# Altium schematic sheet 12_PCIE-x4.SchDoc
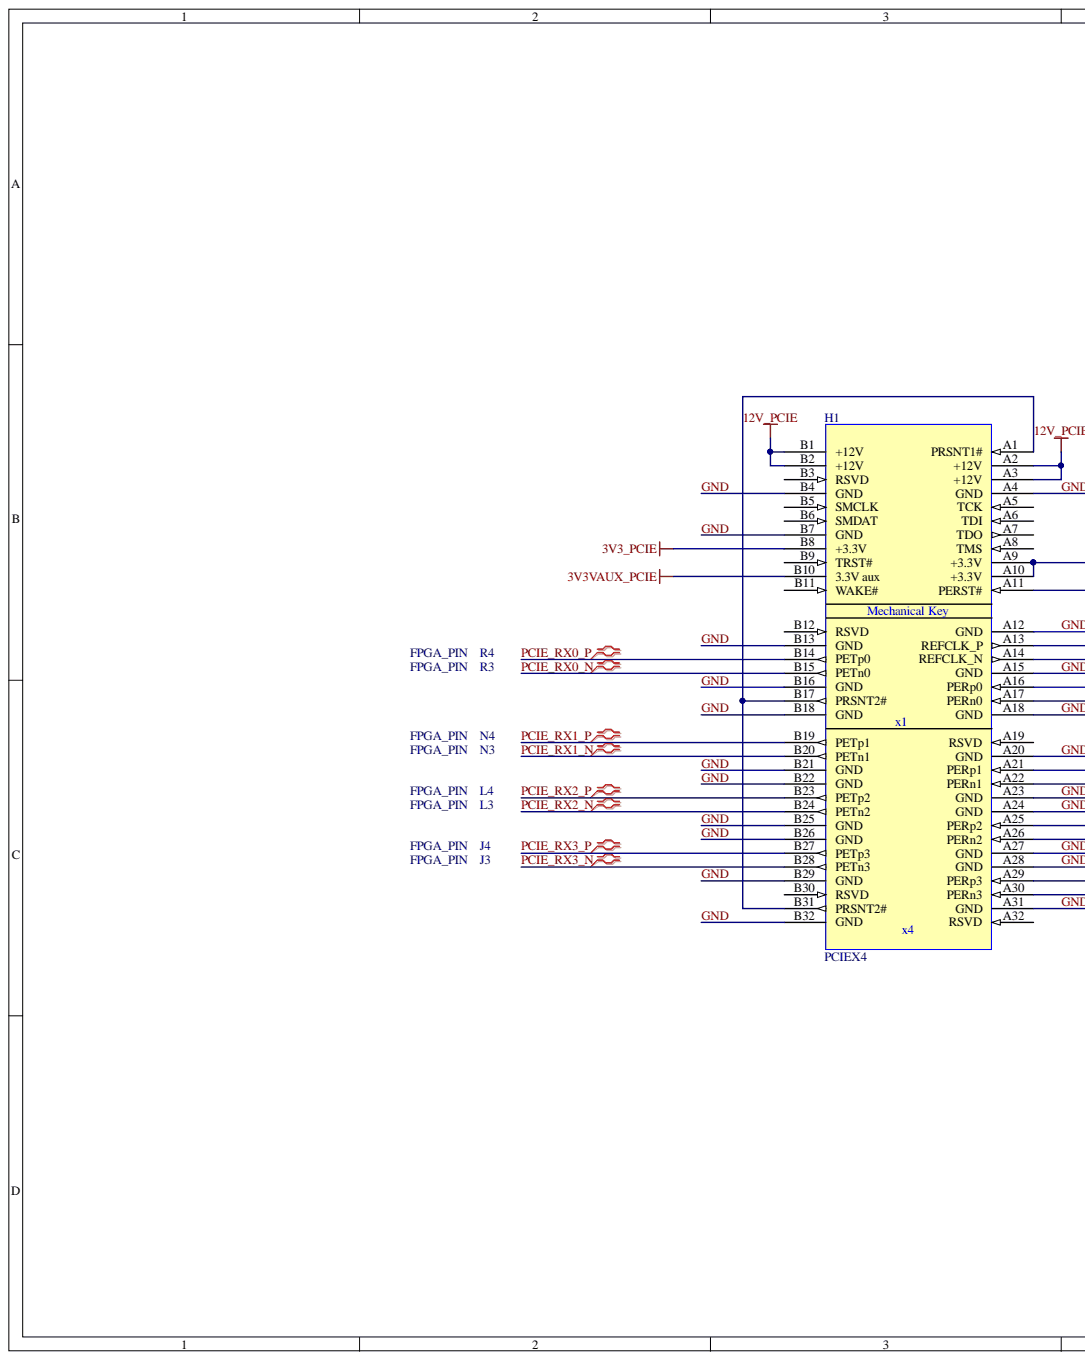
[diagram p0: 12_PCIE-x4.SchDoc, left]
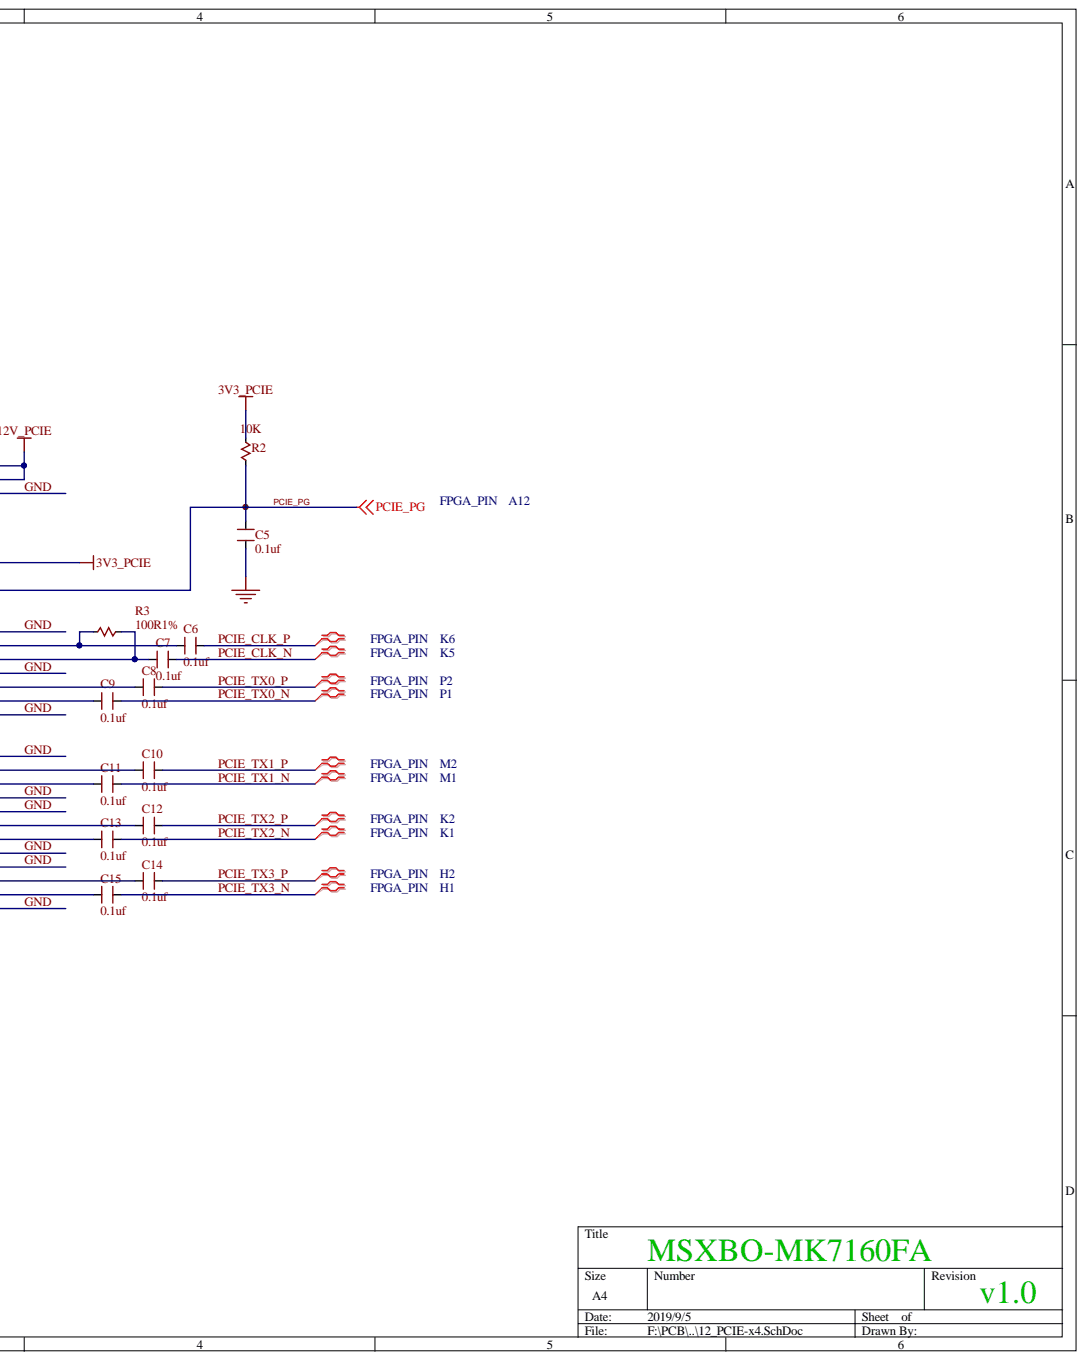
[diagram p1: 12_PCIE-x4.SchDoc, right]

## Parts
C5  0.1uf  [CAP0402]
C6  0.1uf  [CAP0402]
C7  0.1uf  [CAP0402]
C8  0.1uf  [CAP0402]
C9  0.1uf  [CAP0402]
C10  0.1uf  [CAP0402]
C11  0.1uf  [CAP0402]
C12  0.1uf  [CAP0402]
C13  0.1uf  [CAP0402]
C14  0.1uf  [CAP0402]
C15  0.1uf  [CAP0402]
H1  PCIEX4  [PCIEX4]
R2  10K  [R0603]
R3  100R1%  [R0402]

## Nets
3V3_PCIE: H1.A9(+3.3V) H1.A10(+3.3V) H1.B8(+3.3V) R2.1
3V3VAUX_PCIE: H1.B10(3.3V_aux)
12V_PCIE: H1.A2(+12V) H1.A3(+12V) H1.B1(+12V) H1.B2(+12V)
GND: C5.1 H1.A4(GND) H1.A12(GND) H1.A15(GND) H1.A18(GND) H1.A20(GND) H1.A23(GND) H1.A24(GND) H1.A27(GND) H1.A28(GND) H1.A31(GND) H1.B4(GND) H1.B7(GND) H1.B13(GND) H1.B16(GND) H1.B18(GND) H1.B21(GND) H1.B22(GND) H1.B25(GND) H1.B26(GND) H1.B29(GND) H1.B32(GND)
PCIE_CLK_N: C7.2
PCIE_CLK_P: C6.2
PCIE_PG: C5.2 H1.A11(PERST#) R2.2
PCIE_RX0_N: H1.B15(PETn0)
PCIE_RX0_P: H1.B14(PETp0)
PCIE_RX1_N: H1.B20(PETn1)
PCIE_RX1_P: H1.B19(PETp1)
PCIE_RX2_N: H1.B24(PETn2)
PCIE_RX2_P: H1.B23(PETp2)
PCIE_RX3_N: H1.B28(PETn3)
PCIE_RX3_P: H1.B27(PETp3)
PCIE_TX0_N: C9.2
PCIE_TX0_P: C8.2
PCIE_TX1_N: C11.2
PCIE_TX1_P: C10.2
PCIE_TX2_N: C13.2
PCIE_TX2_P: C12.2
PCIE_TX3_N: C15.2
PCIE_TX3_P: C14.2
NetC6_1: C6.1 H1.A13(REFCLK_P) R3.1
NetC7_1: C7.1 H1.A14(REFCLK_N) R3.2
NetC8_1: C8.1 H1.A16(PERp0)
NetC9_1: C9.1 H1.A17(PERn0)
NetC10_1: C10.1 H1.A21(PERp1)
NetC11_1: C11.1 H1.A22(PERn1)
NetC12_1: C12.1 H1.A25(PERp2)
NetC13_1: C13.1 H1.A26(PERn2)
NetC14_1: C14.1 H1.A29(PERp3)
NetC15_1: C15.1 H1.A30(PERn3)
NetH1_A1: H1.A1(PRSNT1#) H1.B17(PRSNT2#) H1.B31(PRSNT2#)
A12:
FPGA_PIN:
H1:
H2:
J3:
J4:
K1:
K2:
K5:
K6:
L3:
L4:
M1:
M2:
N3:
N4:
P1:
P2:
R3:
R4:

Not connected: H1 A5(TCK) A6(TDI) A7(TDO) A8(TMS) A19(RSVD) A32(RSVD) B3(RSVD) B5(SMCLK) B6(SMDAT) B9(TRST#) B11(WAKE#) B12(RSVD) B30(RSVD)

## Library data of the parts
C5-C15 = CAPACITOR: Generic Capacitor; Value: 10nF; Component Kind: Standard; Library Name: MIZ7035.IntLib
R2 R3 = RESISTOR: Generic Resistor; Value: 4.7K; Component Kind: Standard; Library Name: MIZ7035.IntLib
H1 = PCIEX4: Component Kind: Standard; Library Name: MIZ7035_fun.IntLib
